# Altium schematic sheet MCU.SchDot(MCU)
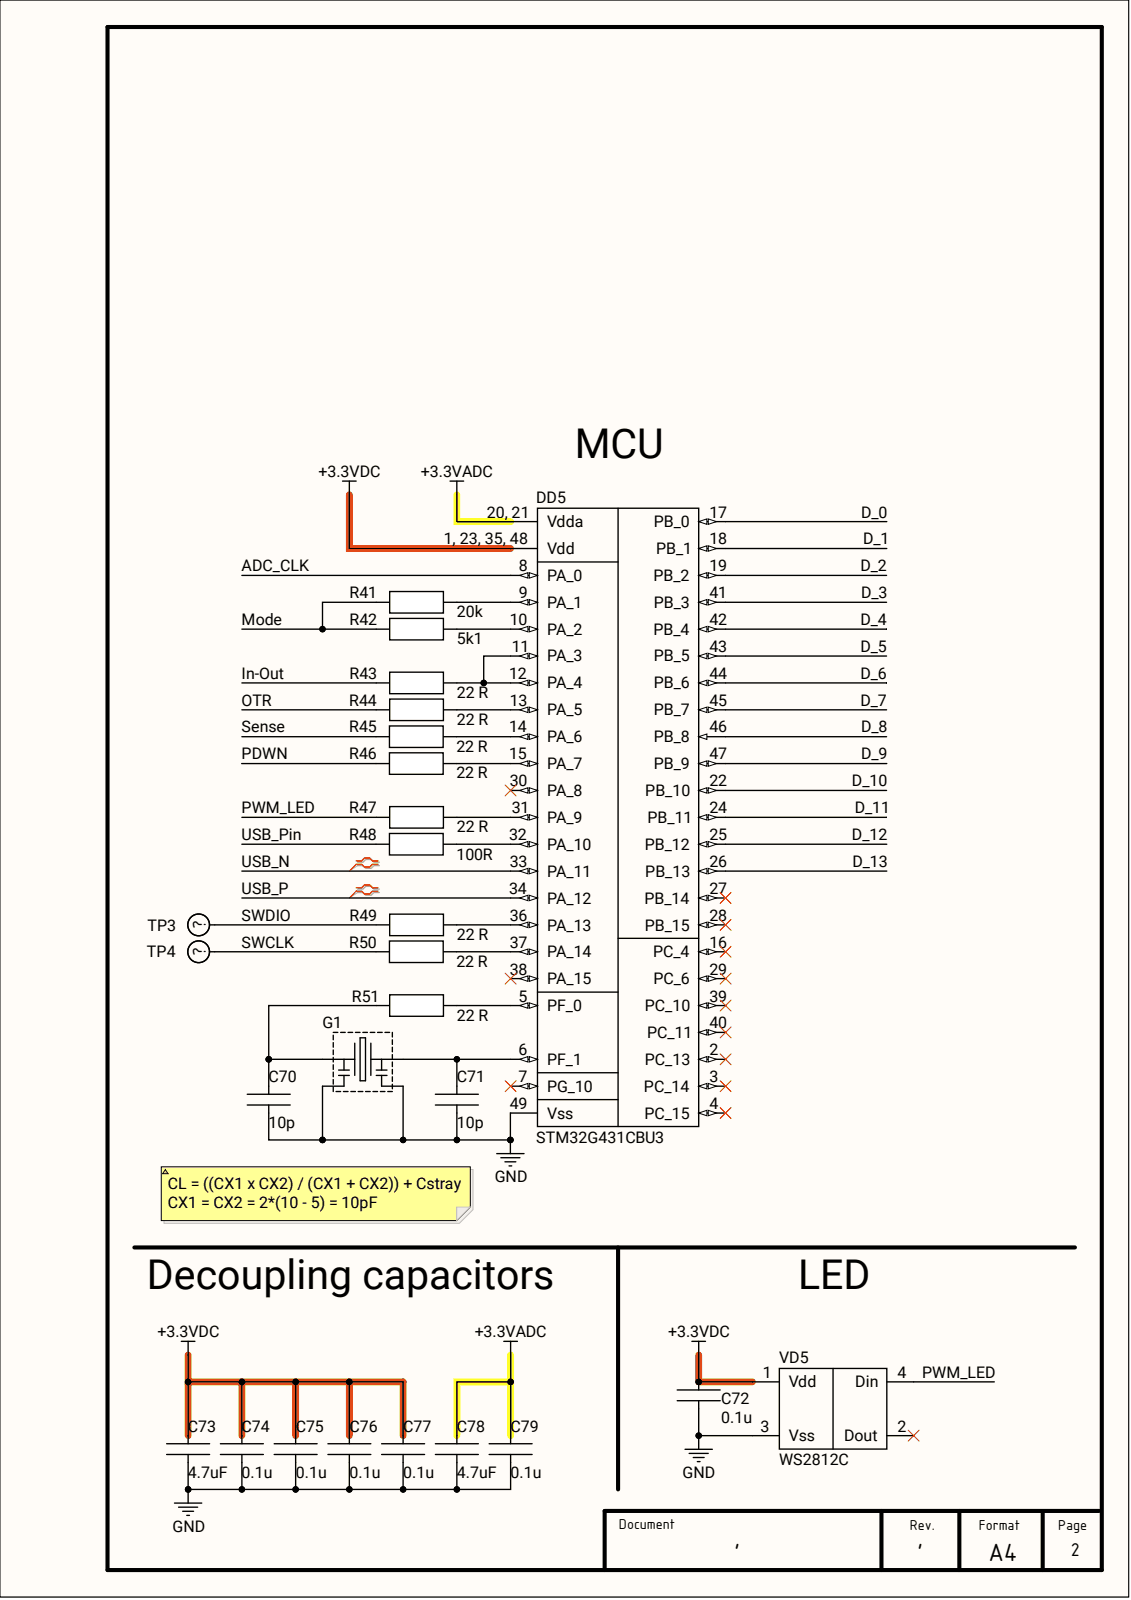
[diagram p0: MCU.SchDot(MCU)]

## Parts
C70  10p  [C0603]
C71  10p  [C0603]
C72  0.1u  [C0603]
C73  4.7uF  [C0603]
C74  0.1u  [C0603]
C75  0.1u  [C0603]
C76  0.1u  [C0603]
C77  0.1u  [C0603]
C78  4.7uF  [C0603]
C79  0.1u  [C0603]
DD5  STM32G431CBU3  [UFQFPN-48]
G1  ECS-320-8-37B2-7KM-TR  [2 mm x 1.6 mm]  (value not drawn)
R41  20k  [R0603]
R42  5k1  [R0603]
R43  22 R  [R0603]
R44  22 R  [R0603]
R45  22 R  [R0603]
R46  22 R  [R0603]
R47  22 R  [R0603]
R48  100R  [R0603]
R49  22 R  [R0603]
R50  22 R  [R0603]
R51  22 R  [R0603]
TP3  5117  [36-5117-ND]  (value not drawn)
TP4  5117  [36-5117-ND]  (value not drawn)
VD5  WS2812C  [SMD5050]

## Nets
+3.3VADC: C78.1 C79.1 DD5.20, 21(Vdda)
+3.3VDC: C72.1 C73.1 C74.1 C75.1 C76.1 C77.1 DD5.1, 23, 35, 48(Vdd) VD5.1(Vdd)
GND: C70.2 C71.2 C72.2 C73.2 C74.2 C75.2 C76.2 C77.2 C78.2 C79.2 DD5.49(Vss) G1.2 G1.4 VD5.3(Vss)
ADC_CLK: DD5.8(PA_0)
D_0: DD5.17(PB_0)
D_1: DD5.18(PB_1)
D_2: DD5.19(PB_2)
D_3: DD5.41(PB_3)
D_4: DD5.42(PB_4)
D_5: DD5.43(PB_5)
D_6: DD5.44(PB_6)
D_7: DD5.45(PB_7)
D_8: DD5.46(PB_8)
D_9: DD5.47(PB_9)
D_10: DD5.22(PB_10)
D_11: DD5.24(PB_11)
D_12: DD5.25(PB_12)
D_13: DD5.26(PB_13)
In-Out: R43.1
Mode: R41.2 R42.1
OTR: R44.1
PDWN: R46.1
PWM_LED: R47.1 VD5.4(Din)
Sense: R45.1
SWCLK: R50.1 TP4.1
SWDIO: R49.1 TP3.1
USB_N: DD5.33(PA_11)
USB_P: DD5.34(PA_12)
USB_Pin: R48.1
NetC70_1: C70.1 G1.1 R51.1
NetC71_1: C71.1 DD5.6(PF_1) G1.3
NetDD5_5: DD5.5(PF_0) R51.2
NetDD5_9: DD5.9(PA_1) R41.1
NetDD5_10: DD5.10(PA_2) R42.2
NetDD5_11: DD5.11(PA_3) DD5.12(PA_4) R43.2
NetDD5_13: DD5.13(PA_5) R44.2
NetDD5_14: DD5.14(PA_6) R45.2
NetDD5_15: DD5.15(PA_7) R46.2
NetDD5_31: DD5.31(PA_9) R47.2
NetDD5_32: DD5.32(PA_10) R48.2
NetDD5_36: DD5.36(PA_13) R49.2
NetDD5_37: DD5.37(PA_14) R50.2

Not connected: DD5 2(PC_13) 3(PC_14) 4(PC_15) 7(PG_10) 16(PC_4) 27(PB_14) 28(PB_15) 29(PC_6) 30(PA_8) 38(PA_15) 39(PC_10) 40(PC_11); VD5 2(Dout)

## Library data of the parts
C70 C71 = MLCC: 50V 10pF C0G 0603 Multilayer Ceramic Capacitors MLCC - SMD/SMT ROHS; Manufacturer: Kyocera AVX; P/N: 06035A100DAT2A; Case: 0603; Component Kind: Standard; Component Type: MLCC; ComponentLink1Description: Link; ComponentLink2Description: Datasheet; Value: 10p; Library Name: Scheet.SchLib
C72 C74-C77 C79 = MLCC: 100V 100nF X7R ±10% 0603 Multilayer Ceramic Capacitors MLCC - SMD/SMT ROHS; Manufacturer: Kyocera AVX; P/N: 06031C104K4T2A; Case: 0603; Component Kind: Standard; Component Type: MLCC; ComponentLink1Description: Link; ComponentLink2Description: Datasheet; Value: 0.1u; Library Name: Scheet.SchLib
  C75: ComponentLink1URL: https://www.lcsc.com/datasheet/lcsc_datasheet_2304140030_Kyocera-AVX-06031C104K4T2A_C1880996.pdf; ComponentLink2URL: https://www.lcsc.com/product-detail/Multilayer-Ceramic-Capacitors-span-style-background-color-ff0-MLCC-span-SMD-SMT_Kyocera-AVX-06031C104K4T2A_C1880996.html
C73 C78 = MLCC: 16V 4.7uF X7S ±10% 0603 Multilayer Ceramic Capacitors MLCC - SMD/SMT ROHS; Manufacturer: Murata Electronics; P/N: GRT188C71C475KE13D; Case: 0603; Component Kind: Standard; Component Type: MLCC; ComponentLink1Description: Link; ComponentLink2Description: Datasheet; Value: 4.7uF; Library Name: Scheet.SchLib
  C73: ComponentLink1URL: https://www.lcsc.com/product-detail/Multilayer-Ceramic-Capacitors-span-style-background-color-ff0-MLCC-span-SMD-SMT_Murata-Electronics-GRT188C71C475KE13D_C5160228.html; ComponentLink2URL: https://www.lcsc.com/datasheet/lcsc_datasheet_2209141730_Murata-Electronics-GRT188C71C475KE13D_C5160228.pdf
DD5 = STM32G431CBU3: ARM Microcontrollers - MCU Mainstream Arm Cortex-M4 MCU 170 MHz 128 Kbytes of Flash Math Accel, Medium Anal; Manufacturer: STMicroelectronics; Value: 170 MHz; Case: UFQFPN-48; Component Kind: Standard; Component Type: ARM Microcontrollers - MCU; ComponentLink1Description: Datasheet; ComponentLink1URL: https://eu.mouser.com/datasheet/2/389/stm32g431c6-1600866.pdf; ComponentLink2Description: Link; ComponentLink2URL: https://eu.mouser.com/ProductDetail/STMicroelectronics/STM32G431CBU3?qs=PzGy0jfpSMuhNWEWgwfZ0w%3D%3D; P/N: STM32G431CBU3; Library Name: Scheet.SchLib
G1 = ECS-320-8-37B2-7KM-TR: Crystals 32.000 MHz 8 pF +/-7 +/-10 -20 +70 C +/-2 ppm Max. ESR = 50; Manufacturer: ECS; Value: 32 MHz,  10 PPM; Case: 2 mm x 1.6 mm; Component Kind: Standard; Component Type: Crystals; ComponentLink1Description: Datasheet; ComponentLink1URL: https://eu.mouser.com/datasheet/2/122/ECX_1637B2-2400564.pdf; ComponentLink2Description: Link; ComponentLink2URL: https://eu.mouser.com/ProductDetail/ECS/ECS-320-8-37B2-7KM-TR?qs=QNEnbhJQKvYRM5nE5d%2F8vg%3D%3D; P/N: ECS-320-8-37B2-7KM-TR; Library Name: Scheet.SchLib
R41 = SMD Resistor: Thick Film Resistors - SMD 0402 1K0 0.5%; Manufacturer: VO; P/N: SCR0603J20K; Case: 0603; Component Kind: Standard; ComponentLink1Description: Datasheet; ComponentLink2Description: Link; Value: 20k; Library Name: Scheet.SchLib
R42 = SMD Resistor: 100mW Thick Film Resistors 75V ±1% 5.1kΩ 0603 Chip Resistor - Surface Mount ROHS; Manufacturer: VO; P/N: SCR0603F5K1; Case: 0603; Component Kind: Standard; ComponentLink1Description: Datasheet; ComponentLink1URL: https://www.lcsc.com/datasheet/lcsc_datasheet_2206021245_VO-SCR0603F5K1_C3016319.pdf; ComponentLink2Description: Link; ComponentLink2URL: https://www.lcsc.com/product-detail/Chip-span-style-background-color-ff0-Resistor-span-Surface-Mount_VO-SCR0603F5K1_C3016319.html; Value: 5k1; Library Name: Scheet.SchLib
R43-R47 R49-R51 = SMD Resistor: Res SMD; Manufacturer: VO; P/N: SCR0603J22R; Case: 0603; Component Kind: Standard; ComponentLink1Description: Datasheet; ComponentLink2Description: Link; Value: 22 R; Library Name: Scheet.SchLib
  R51: ComponentLink1URL: https://www.lcsc.com/datasheet/lcsc_datasheet_2205131800_VO-SCR0603J22R_C3017773.pdf; ComponentLink2URL: https://www.lcsc.com/product-detail/Chip-span-style-background-color-ff0-Resistor-span-Surface-Mount_VO-SCR0603J22R_C3017773.html
R48 = SMD Resistor: 100mW Thick Film Resistors 75V ±100ppm/℃ ±5% 100Ω 0603 Chip Resistor - Surface Mount ROHS; Manufacturer: RALEC; P/N: RTT03101JTP; Case: 0603; Component Kind: Standard; ComponentLink1Description: Datasheet; ComponentLink2Description: Link; Value: 100R; Library Name: Scheet.SchLib
TP3 TP4 = (no symbol name): PCB TEST POINT BLUE; Manufacturer: Keystone Electronics; Value: 36-5117; Case: THT; Component Kind: Standard; Component Type: Test point; ComponentLink1Description: Datasheet; ComponentLink1URL: https://ru.mouser.com/datasheet/2/215/5115_5119-744073.pdf; ComponentLink2Description: Link; ComponentLink2URL: https://ru.mouser.com/ProductDetail/Keystone-Electronics/5117?qs=%2Fha2pyFadujSuVybhyFc6oqj%252BXCGMBBx2uPmIz4OBqU%3D; Library Name: Others.SchLib; P/N: 5117
VD5 = WS2812: RGB SMD5050 Light Emitting Diodes (LED) ROHS; Manufacturer: Worldsemi; Case: SMD5050; Component Kind: Standard; Component Type: RGB LED; ComponentLink1Description: Datasheet; ComponentLink1URL: https://datasheet.lcsc.com/lcsc/1810231210_Worldsemi-WS2812C_C114587.pdf; ComponentLink2Description: Link; ComponentLink2URL: https://www.lcsc.com/product-detail/Light-Emitting-Diodes-LED_Worldsemi-WS2812C_C114587.html; P/N: WS2812C; Value: RGB; Library Name: Scheet.SchLib
